# Altium schematic sheet POWER.SchDoc
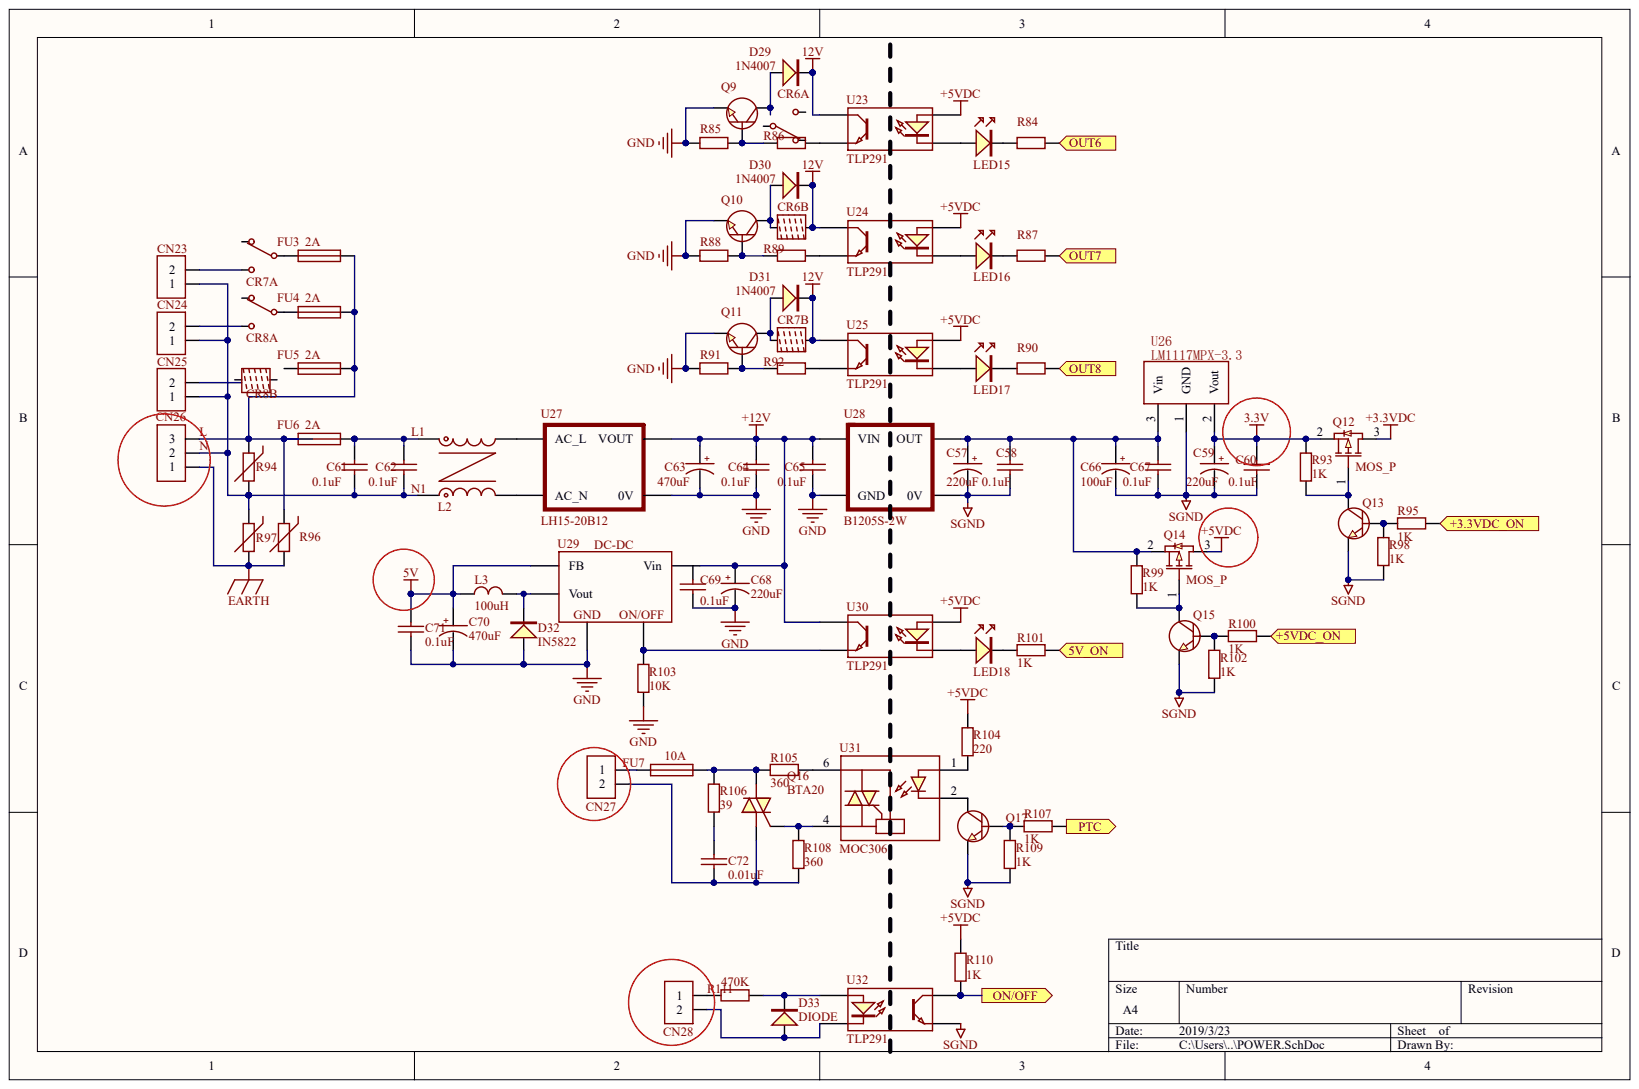
[diagram p0: POWER.SchDoc]

## Parts
C57  220uF
C58  0.1uF
C59  220uF
C60  0.1uF
C61  0.1uF
C62  0.1uF
C63  470uF
C64  0.1uF
C65  0.1uF
C66  100uF
C67  0.1uF
C68  220uF
C69  0.1uF
C70  470uF
C71  0.1uF
C72  0.01uF
CN23  CON2  (value not drawn)
CN24  CON2  (value not drawn)
CN25  CON2  (value not drawn)
CN26  CON3  (value not drawn)
CN27  CON2  (value not drawn)
CN28  CON2  (value not drawn)
CR6  继电器  (value not drawn)  (parts A,B)
CR7  继电器  (value not drawn)  (parts A,B)
CR8  继电器  (value not drawn)  (parts A,B)
D29  1N4007
D30  1N4007
D31  1N4007
D32  IN5822
D33  DIODE
FU3  2A
FU4  2A
FU5  2A
FU6  2A
FU7  10A
L2  共模电感  (value not drawn)
L3  100uH
LED15  Led  (value not drawn)
LED16  Led  (value not drawn)
LED17  Led  (value not drawn)
LED18  Led  (value not drawn)
Q9  1318  (value not drawn)
Q10  1318  (value not drawn)
Q11  1318  (value not drawn)
Q12  MOS_P
Q13  1318  (value not drawn)
Q14  MOS_P
Q15  1318  (value not drawn)
Q16  BTA20
Q17  1318  (value not drawn)
R84  RES  (value not drawn)
R85  10K  (value not drawn)
R86  10K  (value not drawn)
R87  RES  (value not drawn)
R88  10K  (value not drawn)
R89  10K  (value not drawn)
R90  RES  (value not drawn)
R91  10K  (value not drawn)
R92  10K  (value not drawn)
R93  1K
R94  431K10D  (value not drawn)
R95  1K
R96  431K10D  (value not drawn)
R97  431K10D  (value not drawn)
R98  1K
R99  1K
R100  1K
R101  1K
R102  1K
R103  10K
R104  220
R105  360
R106  39
R107  1K
R108  360
R109  1K
R110  1K
R111  470K
U23  TLP291
U24  TLP291
U25  TLP291
U26  LM1117MPX-3.3
U27  LH15-20B12
U28  B1205S-2W
U29  DC-DC
U30  TLP291
U31  MOC3061
U32  TLP291

## Ports
5V_ON, +3.3VDC_ON, +5VDC_ON, ON/OFF, OUT6, OUT7, OUT8, PTC

## Nets
3.3V: C59.1 C60.1 Q12.2 R93.1 U26.2
5V: C70.1 C71.1 L3.2 U29.4(FB)
12V: CR6.5 CR7.5 D29.K D30.K D31.K U23.4 U24.4 U25.4
+3.3VDC: Q12.3
+5VDC: Q14.3 R104.2 R110.2 U23.1 U24.1 U25.1 U30.1
+12V: C63.1 C64.1 C65.1 C68.1 U27.8(VOUT) U28.1(VIN) U29.1(Vin) U30.4
EARTH: CN26.1 R96.1 R97.1
GND: C63.2 C64.2 C65.2 C68.2 C69.2 C70.2 C71.2 D32.A Q9.2 Q10.2 Q11.2 R85.2 R88.2 R91.2 R103.2 U27.4(0V) U28.2(GND) U29.3
SGND: C57.2 C58.2 C59.2 C60.2 C66.2 C67.2 Q13.2 Q15.2 Q17.2 R98.1 R102.1 R109.1 U26.1 U28.4(0V) U32.3
5V_ON: R101.1 ; port 5V_ON
+3.3VDC_ON: R95.1 ; port +3.3VDC_ON
+5VDC_ON: R100.1 ; port +5VDC_ON
L: CN26.3 FU3.2 FU4.2 FU5.2 FU6.1 R94.2 R96.2
L1: C61.1 C62.1 FU6.2 L2.1
N: C61.2 C62.2 CN23.1 CN24.1 CN25.1 CN26.2 L2.2 R94.1 R97.2
ON/OFF: R110.1 U32.4 ; port ON/OFF
OUT6: R84.1 ; port OUT6
OUT7: R87.1 ; port OUT7
OUT8: R90.1 ; port OUT8
PTC: R107.1 ; port PTC
NetC57_1: C57.1 C58.1 C66.1 C67.1 Q14.2 R99.1 U26.3 U28.6(OUT)
NetC72_1: C72.1 R106.1
NetC72_2: C72.2 CN27.2 Q16.1 R108.1
NetCN23_2: CN23.2 CR7.3
NetCN24_2: CN24.2 CR8.3
NetCN27_1: CN27.1 FU7.1
NetCN28_1: CN28.1 R111.2
NetCN28_2: CN28.2 D33.A U32.2
NetCR6_1: CR6.1 D30.A Q10.3
NetCR7_1: CR7.1 D31.A Q11.3
NetCR7_4: CR7.4 FU3.1
NetCR8_4: CR8.4 FU4.1
NetD29_A: D29.A Q9.3
NetD32_K: D32.K L3.1 U29.2(Vout)
NetD33_K: D33.K R111.1 U32.1
NetFU7_2: FU7.2 Q16.2 R105.2 R106.2
NetL2_3: L2.3 U27.2(AC_N)
NetL2_4: L2.4 U27.3(AC_L)
NetLED15_A: LED15.A U23.2
NetLED15_K: LED15.K R84.2
NetLED16_A: LED16.A U24.2
NetLED16_K: LED16.K R87.2
NetLED17_A: LED17.A U25.2
NetLED17_K: LED17.K R90.2
NetLED18_A: LED18.A U30.2
NetLED18_K: LED18.K R101.2
NetQ9_1: Q9.1 R85.1 R86.2
NetQ10_1: Q10.1 R88.1 R89.2
NetQ11_1: Q11.1 R91.1 R92.2
NetQ12_1: Q12.1 Q13.3 R93.2
NetQ13_1: Q13.1 R95.2 R98.2
NetQ14_1: Q14.1 Q15.3 R99.2
NetQ15_1: Q15.1 R100.2 R102.2
NetQ16_3: Q16.3 R108.2 U31.4
NetQ17_1: Q17.1 R107.2 R109.2
NetQ17_3: Q17.3 U31.2
NetR86_1: R86.1 U23.3
NetR89_1: R89.1 U24.3
NetR92_1: R92.1 U25.3
NetR103_1: R103.1 U29.5 U30.3
NetR104_1: R104.1 U31.1
NetR105_1: R105.1 U31.6

Not connected: C69 1; CN25 2; CR6 2(R86) 3 4; CR7 2; CR8 1 2 5; FU5 1

## Library data of the parts
C58 C60-C62 C64 C65 C67 C69 C71 = (no symbol name): 风华: 0603CG200J500NT; 三星: CL10C200JB8NNNC; 三星: CL10B104JB8NNNC; 风华: 0603B104J500NT
CN23-CN25 CN27 CN28 = (no symbol name): KANGNEX(康奈克斯电气): HB9500-2P 黑色
L2 = (no symbol name): 金升阳: FL2D-Z5-103
Q16 = (no symbol name): ST(意法半导体): BTA20-600CWRG
R93 R95 R98-R105 R107-R110 = (no symbol name): 风华: RS-06K3600FT
R106 = (no symbol name): 风华: RS-06K390JT
U31 = (no symbol name): ON(安森美): MOC3061SR2M
C57 C59 C63 C66 C68 C70 C72 CN26 CR6-CR8 D29-D33 FU3-FU7 L3 LED15-LED18 Q9-Q15 Q17 R84-R92 R94 R96 R97 R111 U23-U30 U32 = (no symbol name)
  CR6-CR8: Published: 24-Mar-1999; LatestRevisionDate: 17-Jul-2002; LatestRevisionNote: Re-released for DXP Platform.; PackageReference: DIP-P8; Publisher: Altium Limited
